# Altium schematic sheet 50 Pin Headers.SchDoc("50 Pin Headers")
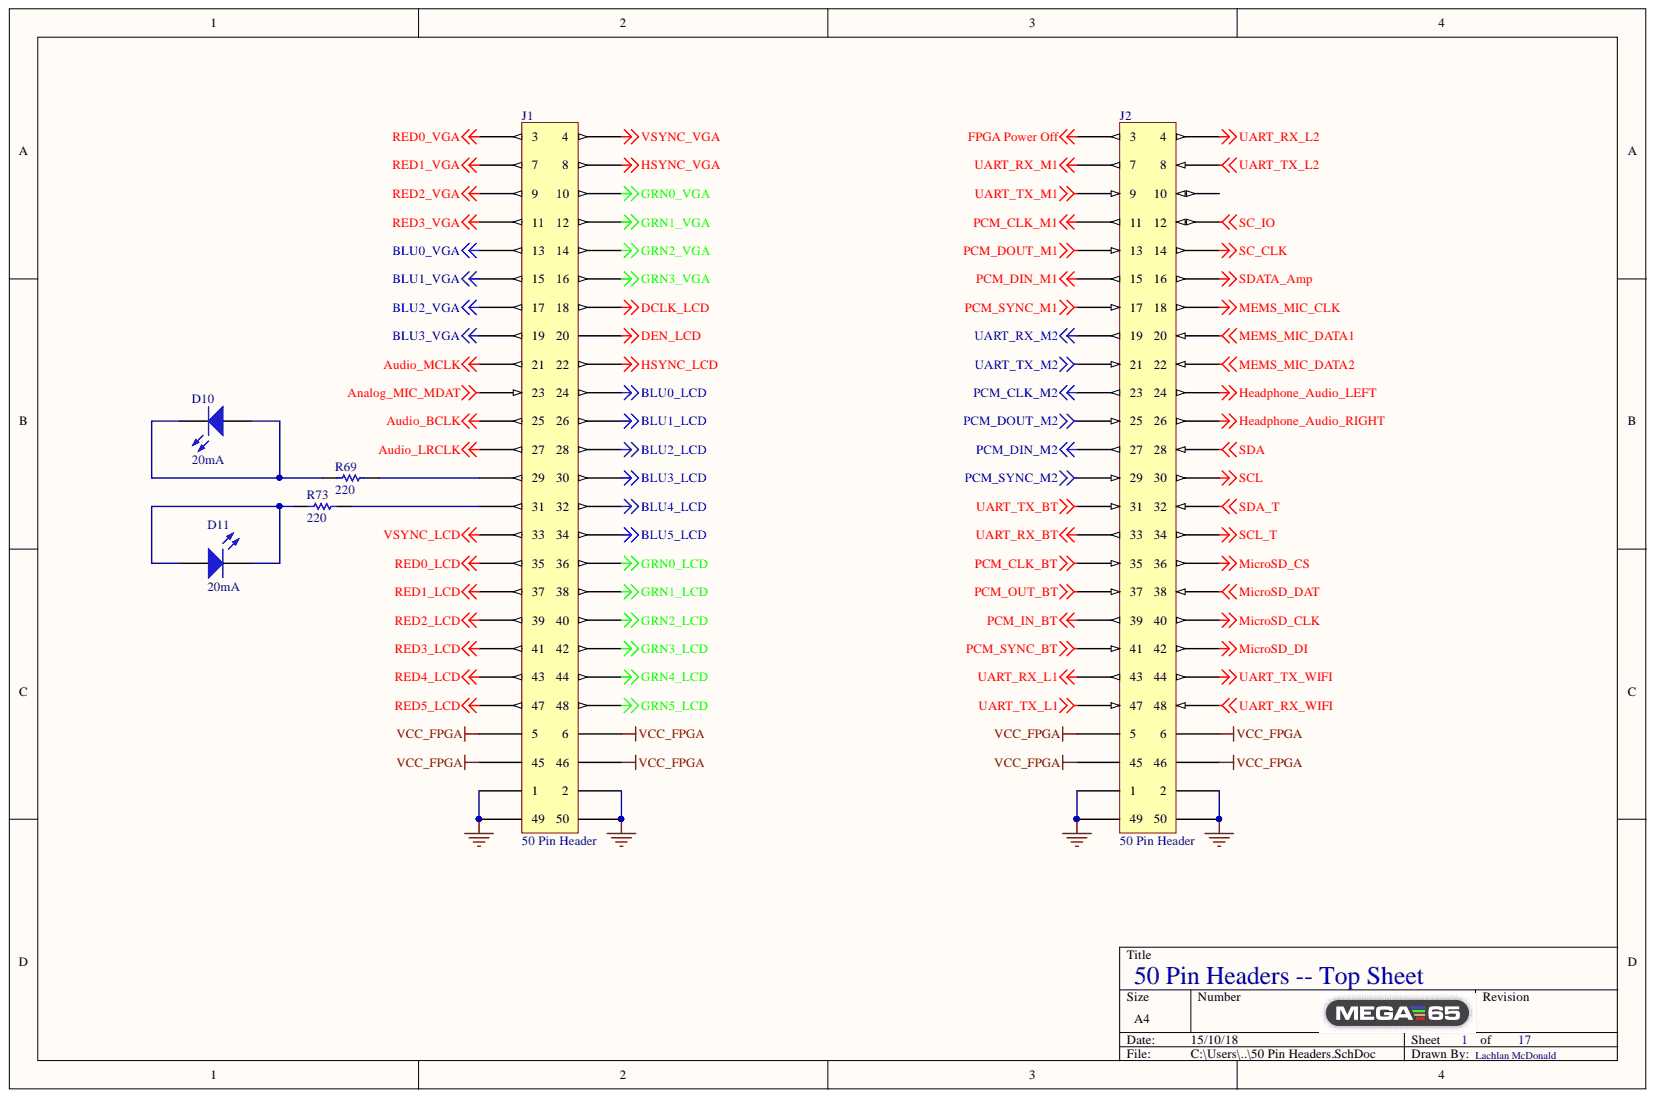
[diagram p0: 50 Pin Headers.SchDoc]

## Parts
D10  20mA  [LEDs]
D11  20mA  [LEDs]
J1  50 Pin Header  [Female Header 50 Pin]
J2  50 Pin Header  [Female Header 50 Pin]
R69  220  [RESC0805(2012)_M]
R73  220  [RESC0805(2012)_M]

## Nets
Analog_MIC_MDAT: J1.23
Audio_BCLK: J1.25
Audio_LRCLK: J1.27
Audio_MCLK: J1.21
BLU0_LCD: J1.24
BLU0_VGA: J1.13
BLU1_LCD: J1.26
BLU1_VGA: J1.15
BLU2_LCD: J1.28
BLU2_VGA: J1.17
BLU3_LCD: J1.30
BLU3_VGA: J1.19
BLU4_LCD: J1.32
BLU5_LCD: J1.34
DCLK_LCD: J1.18
DEN_LCD: J1.20
FPGA Power Off: J2.3
GND: J1.1 J1.2 J1.49 J1.50 J2.1 J2.2 J2.49 J2.50
GRN0_LCD: J1.36
GRN0_VGA: J1.10
GRN1_LCD: J1.38
GRN1_VGA: J1.12
GRN2_LCD: J1.40
GRN2_VGA: J1.14
GRN3_LCD: J1.42
GRN3_VGA: J1.16
GRN4_LCD: J1.44
GRN5_LCD: J1.48
Headphone_Audio_LEFT: J2.24
Headphone_Audio_RIGHT: J2.26
HSYNC_LCD: J1.22
HSYNC_VGA: J1.8
MEMS_MIC_CLK: J2.18
MEMS_MIC_DATA1: J2.20
MEMS_MIC_DATA2: J2.22
MicroSD_CLK: J2.40
MicroSD_CS: J2.36
MicroSD_DAT: J2.38
MicroSD_DI: J2.42
PCM_CLK_BT: J2.35
PCM_CLK_M1: J2.11
PCM_CLK_M2: J2.23
PCM_DIN_M1: J2.15
PCM_DIN_M2: J2.27
PCM_DOUT_M1: J2.13
PCM_DOUT_M2: J2.25
PCM_IN_BT: J2.39
PCM_OUT_BT: J2.37
PCM_SYNC_BT: J2.41
PCM_SYNC_M1: J2.17
PCM_SYNC_M2: J2.29
RED0_LCD: J1.35
RED0_VGA: J1.3
RED1_LCD: J1.37
RED1_VGA: J1.7
RED2_LCD: J1.39
RED2_VGA: J1.9
RED3_LCD: J1.41
RED3_VGA: J1.11
RED4_LCD: J1.43
RED5_LCD: J1.47
SC_CLK: J2.14
SC_IO: J2.12
SCL: J2.30
SCL_T: J2.34
SDA: J2.28
SDA_T: J2.32
SDATA_Amp: J2.16
UART_RX_BT: J2.33
UART_RX_L1: J2.43
UART_RX_L2: J2.4
UART_RX_M1: J2.7
UART_RX_M2: J2.19
UART_RX_WIFI: J2.48
UART_TX_BT: J2.31
UART_TX_L1: J2.47
UART_TX_L2: J2.8
UART_TX_M1: J2.9
UART_TX_M2: J2.21
UART_TX_WIFI: J2.44
VCC_FPGA: J1.5 J1.6 J1.45 J1.46 J2.5 J2.6 J2.45 J2.46
VSYNC_LCD: J1.33
VSYNC_VGA: J1.4
NetD10_1: D10.1 D10.2 R69.1
NetD11_1: D11.1 D11.2 R73.1
NetJ1_29: J1.29 R69.2
NetJ1_31: J1.31 R73.2

Not connected: J2 10

## Library data of the parts
D10 D11 = LED2: Typical RED, GREEN, YELLOW, AMBER GaAs LED; Simulation: LED2; Published: 8-Jun-2000; PackageVersion: Feb-2002; PackageReference: 3.2X1.6X1.1; PackageDescription: SM LED; 2 Flat Leads; Library Name: Miscellaneous Devices.IntLib; LatestRevisionNote: Re-released for DXP Platform.; LatestRevisionDate: 17-Jul-2002; Component Kind: Standard; Publisher: Altium Limited
R69 R73 = CMP-1013-00623-1: 10K 0.125W 1% 0805 (2012 Metric)  SMD; Value: 10000.00 Ohm; Case-Metric: 2012; Case-EIA: 0805; Tolerance: 1 %; Technology: SMT; Power: 0.125 W; Library Name: Altium Content Vault; Component Kind: Standard
J1 J2 = 50 Pin Header: Library Name: Schlib1.SchLib; Component Kind: Standard
